# Altium schematic sheet Sheet1.SchDoc(Sheet1)
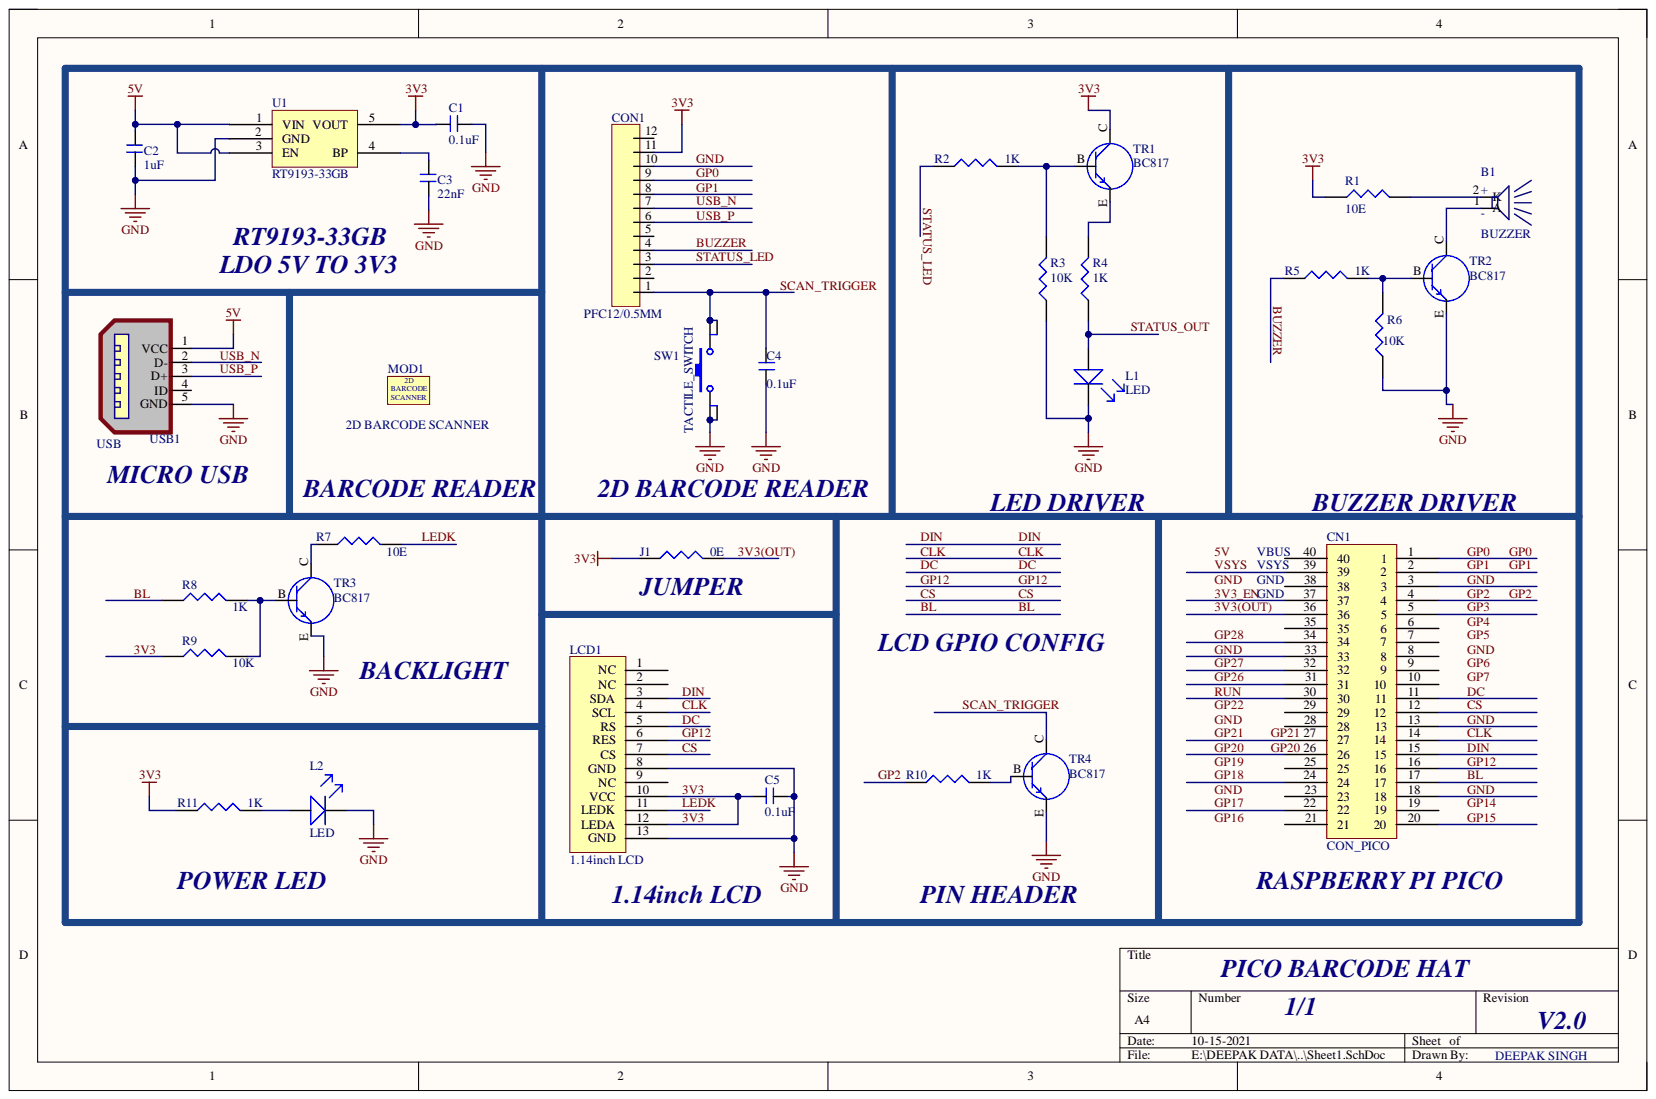
[diagram p0: Sheet1.SchDoc]

## Parts
B1  BUZZER  [BUZZER_9*3.2 SMD]
C1  0.1uF  [CAP_0603_NONPOLAR]
C2  1uF  [CAP_0603_NONPOLAR]
C3  22nF  [CAP_0603_NONPOLAR]
C4  0.1uF  [CAP_0603_NONPOLAR]
C5  0.1uF  [CAP_0603_NONPOLAR]
CN1  CON_PICO  [CON40_PICO_TOP FEMALE SMT]
CON1  PFC12/0.5MM  [FPC CONNECTOR -12PIN]
J1  0E  [0603]
L1  LED  [LED_0603_RED]
L2  LED  [LED_0603_RED]
LCD1  1.14inch LCD  [1.14 inch LCD C]
MOD1  2D BARCODE SCANNER  [2D BARCODE SCANNER]
R1  10E  [0603]
R2  1K  [0603]
R3  10K  [0603]
R4  1K  [0603]
R5  1K  [0603]
R6  10K  [0603]
R7  10E  [0603]
R8  1K  [0603]
R9  10K  [0603]
R10  1K  [0603]
R11  1K  [0603]
SW1  TACTILE_SWITCH  [SKRPACE010]
TR1  BC817  [547_SOT23]
TR2  BC817  [547_SOT23]
TR3  BC817  [547_SOT23]
TR4  BC817  [547_SOT23]
U1  RT9193-33GB  [SOT-23-5]
USB1  USB  [USB_MICRO 2]

## Nets
3V3: C1.2 C5.2 CON1.11 J1.1 LCD1.10(VCC) LCD1.12(LEDA) R1.1 R9.1 R11.1 TR1.C U1.5(VOUT)
3V3(OUT): CN1.36 J1.2
3V3_EN: CN1.37
5V: C2.1 U1.1(VIN) U1.3(EN) USB1.1(VCC)
BL: CN1.17 R8.1
BUZZER: CON1.4 R5.1
CLK: CN1.14 LCD1.4(SCL)
CS: CN1.12 LCD1.7(CS)
DC: CN1.11 LCD1.5(RS)
DIN: CN1.15 LCD1.3(SDA)
GND: C1.1 C2.2 C3.2 C4.1 C5.1 CN1.3 CN1.13 CN1.18 CN1.33 CON1.10 L1.K L2.K LCD1.8(GND) LCD1.13(GND) R3.1 R6.1 SW1.1 SW1.2 TR2.E TR3.E TR4.E U1.2(GND) USB1.5(GND)
GP0: CN1.1 CON1.9
GP1: CN1.2 CON1.8
GP2: CN1.4 R10.1
GP3: CN1.5
GP12: CN1.16 LCD1.6(RES)
GP15: CN1.20
GP17: CN1.22
GP18: CN1.24
GP20: CN1.26
GP21: CN1.27
GP26: CN1.31
GP27: CN1.32
GP28: CN1.34
LEDK: LCD1.11(LEDK) R7.2
RUN: CN1.30
SCAN_TRIGGER: C4.2 CON1.1 SW1.3 SW1.4 TR4.C
STATUS_LED: CON1.3 R2.1
STATUS_OUT: L1.A R4.1
USB_N: CON1.7 USB1.2(D-)
USB_P: CON1.6 USB1.3(D+)
VSYS: CN1.39
NetB1_1: B1.1 TR2.C
NetB1_2: B1.2 R1.2
NetC3_1: C3.1 U1.4(BP)
NetL2_A: L2.A R11.2
NetR2_2: R2.2 R3.2 TR1.B
NetR4_2: R4.2 TR1.E
NetR5_2: R5.2 R6.2 TR2.B
NetR7_1: R7.1 TR3.C
NetR8_2: R8.2 R9.2 TR3.B
NetR10_2: R10.2 TR4.B
GP4:
GP5:
GP6:
GP7:
GP14:
GP16:
GP19:
GP22:

Not connected: CN1 6 7 8 9 10 19 21 23 25 28 29 35 38 40; CON1 2 5 12; LCD1 1(NC) 2(NC) 9(NC); USB1 4(ID)

## Library data of the parts
B1 = BUZZER PIEZO: Component Kind: Standard; Library Name: dxp_pasl_lib.IntLib
C1-C5 = CAP_NONPOLAR: Component Kind: Standard; Library Name: dxp_pasl_lib.IntLib
CN1 = CON40_PICO TOP MALE BERG: Component Kind: Standard; Library Name: dxp_pasl_lib.IntLib
J1 R1-R11 = RES: Component Kind: Standard; Library Name: dxp_pasl_lib.IntLib
LCD1 = LCD 1.14INCH: 1.14inch LCD Display 65K RGB Colors, 240×135 Pixels, SPI Interface; Component Kind: Standard; Library Name: dxp_pasl_lib.IntLib
TR1-TR4 = TRANSISTER_NPN: Component Kind: Standard; Library Name: dxp_pasl_lib.IntLib
U1 = RT9193-33: Component Kind: Standard; Library Name: dxp_pasl_lib.IntLib
USB1 = USB_MICRO: Micro USB Connector SMD – TH Support Legs – 5 Pin – Type B; Component Kind: Standard; Library Name: dxp_pasl_lib.IntLib
CON1 = PFC12/0.5MM: Component Kind: Standard; Library Name: dxp_pasl_lib.IntLib
L1 L2 = LED: Component Kind: Standard; Library Name: dxp_pasl_lib.IntLib
MOD1 = 2D BARCODE SCANNER: Component Kind: Standard; Library Name: dxp_pasl_lib.IntLib
SW1 = TACTILE_SWITCH: Component Kind: Standard; Library Name: dxp_pasl_lib.IntLib
